# Altium schematic sheet display QVGA.SchDoc("display QVGA")
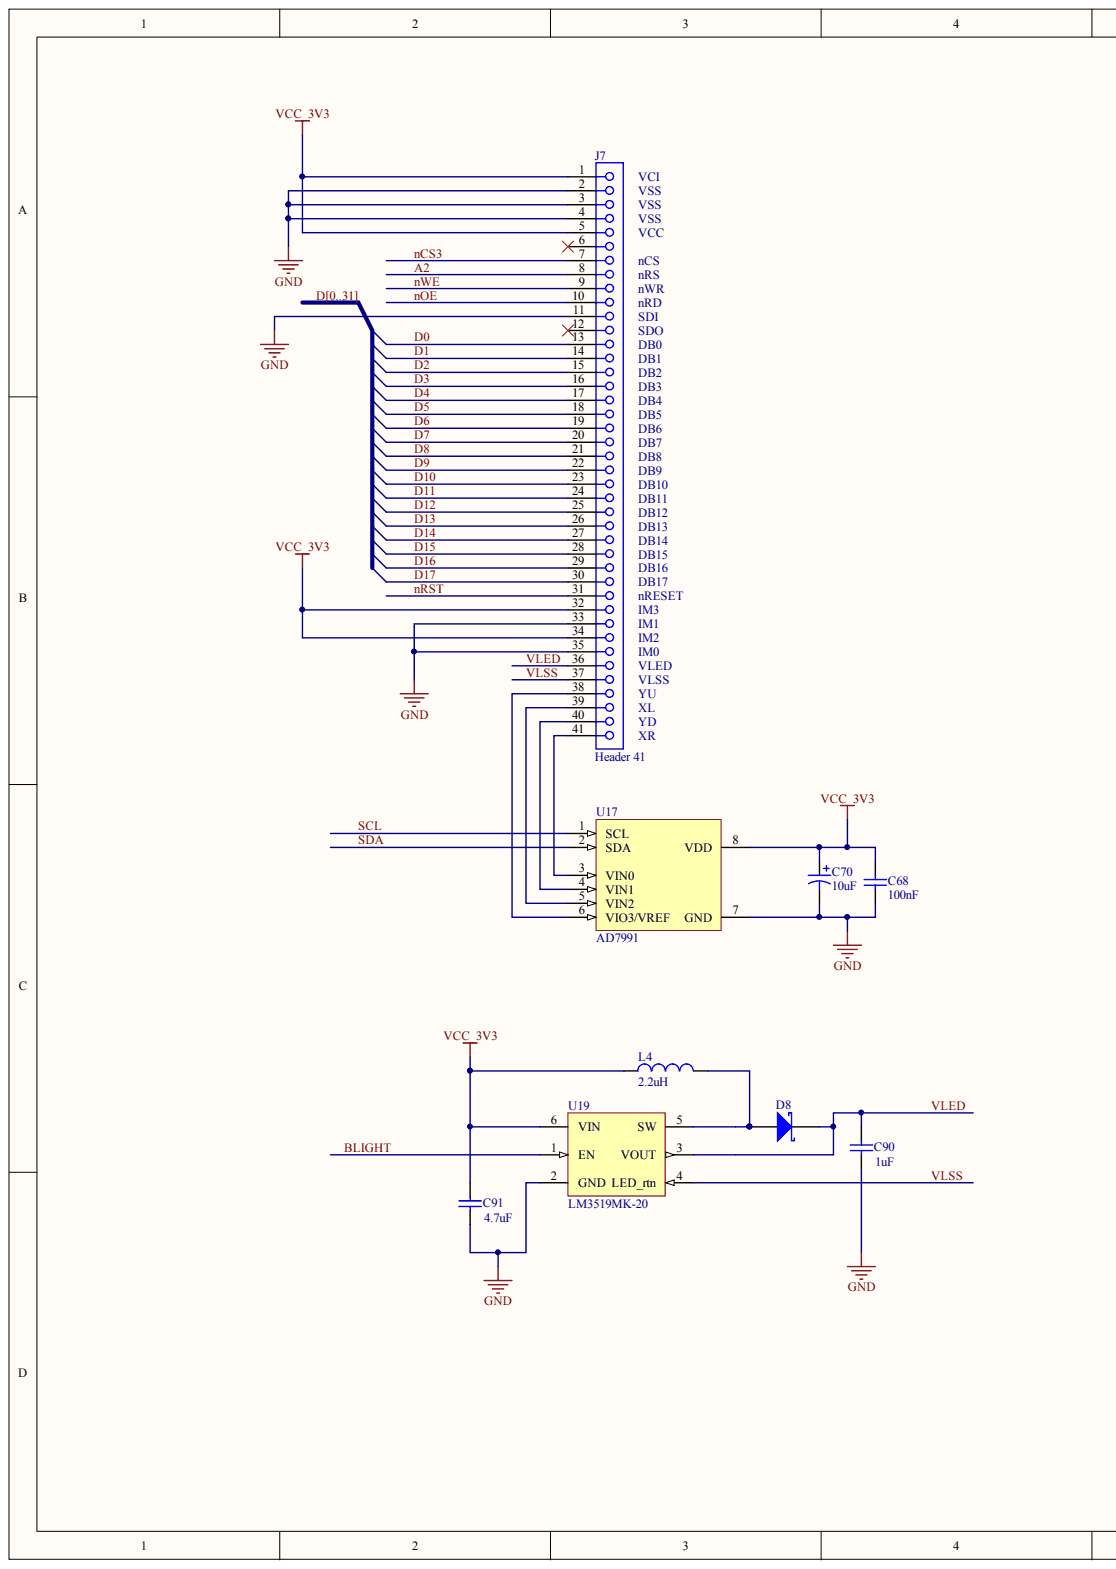
[diagram p0: display QVGA.SchDoc, left]
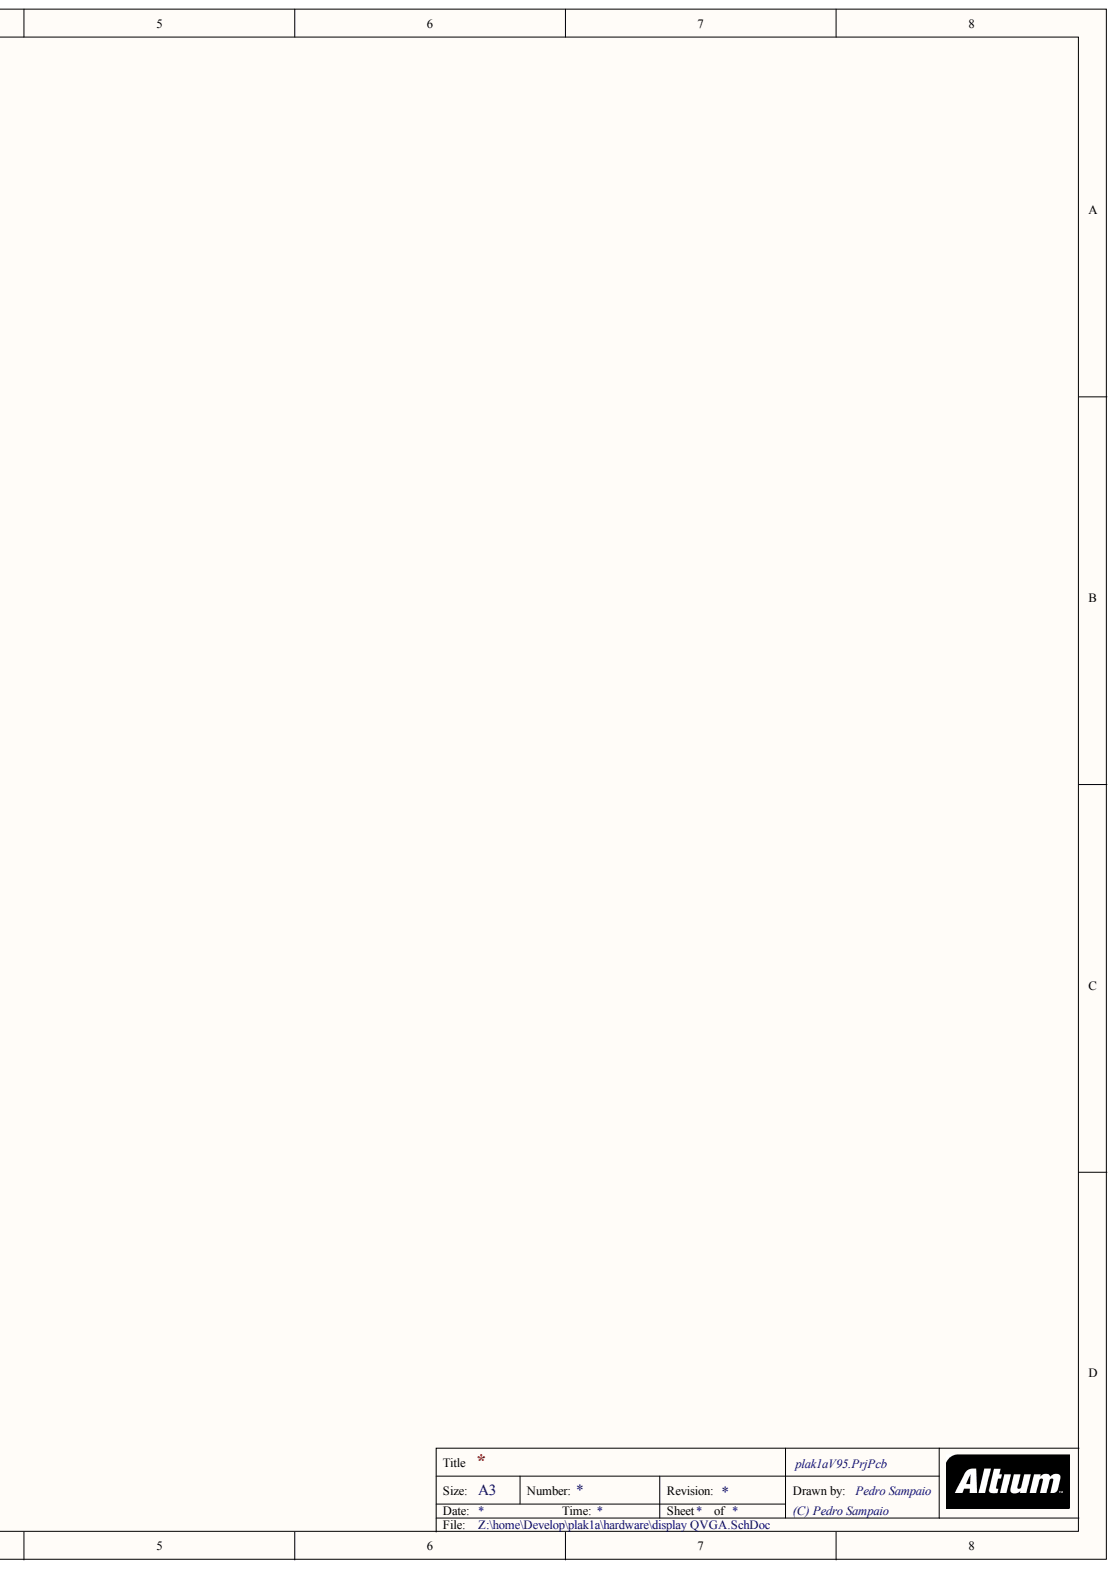
[diagram p1: display QVGA.SchDoc, right]

## Parts
C68  value 100nF  [1608[0603]]  (hidden comment: 9402101)
C70  value 10uF  [B]  (hidden comment: T491B)
C90  value 1uF  [2012[0805]]  (hidden comment: 8805628)
C91  value 4.7uF  [2012[0805]]  (hidden comment: 8805628)
D8  SS12  [SMB]  (value not drawn)
J7  Header 41  [04 6295 041 000 883+]
L4  value 2.2uH  [4564_Chip]  (hidden comment: Inductor)
U17  AD7991  [RJ-8]
U19  LM3519MK-20  [MK06A_N]

## Nets
GND: C68.1 C70.2 C90.1 C91.1 J7.2 J7.3 J7.4 J7.11 J7.33 J7.35 U17.7(GND) U19.2(GND)
VCC_3V3: C68.2 C70.1 C91.2 J7.1 J7.5 J7.32 J7.34 L4.1 U17.8(VDD) U19.6(VIN)
A2: J7.8
BLIGHT: U19.1(EN)
D0: J7.13  [also labelled D]
D1: J7.14  [also labelled D]
D2: J7.15  [also labelled D]
D3: J7.16  [also labelled D]
D4: J7.17  [also labelled D]
D5: J7.18  [also labelled D]
D6: J7.19  [also labelled D]
D7: J7.20  [also labelled D]
D8: J7.21  [also labelled D]
D9: J7.22  [also labelled D]
D10: J7.23  [also labelled D]
D11: J7.24  [also labelled D]
D12: J7.25  [also labelled D]
D13: J7.26  [also labelled D]
D14: J7.27  [also labelled D]
D15: J7.28  [also labelled D]
D16: J7.29  [also labelled D]
D17: J7.30  [also labelled D]
NCS3: J7.7
NOE: J7.10
NRST: J7.31
NWE: J7.9
SCL: U17.1(SCL)
SDA: U17.2(SDA)
VLED: C90.2 D8.2 J7.36 U19.3(VOUT)
VLSS: J7.37 U19.4(LED_rtn)
NetD8_1: D8.1 L4.2 U19.5(SW)
NetJ7_38: J7.38 U17.6(VIO3/VREF)
NetJ7_39: J7.39 U17.5(VIN2)
NetJ7_40: J7.40 U17.4(VIN1)
NetJ7_41: J7.41 U17.3(VIN0)
D18:  [also labelled D]
D19:  [also labelled D]
D20:  [also labelled D]
D21:  [also labelled D]
D22:  [also labelled D]
D23:  [also labelled D]
D24:  [also labelled D]
D25:  [also labelled D]
D26:  [also labelled D]
D27:  [also labelled D]
D28:  [also labelled D]
D29:  [also labelled D]
D30:  [also labelled D]
D31:  [also labelled D]

Not connected: J7 6 12

## Library data of the parts
C68 = C0603: Ceramic Chip Capacitor - Standard; Note: Footprint corresponds to dimensions for bulk cassette packaging. This case size has tightened tolerances.; Code_IPC: 1608 [0603]; Code_JEITA: 0603; Component Kind: Standard; ComponentLink1Description: Manufacturer Link; ComponentLink1URL: http://www.kemet.com/; ComponentLink2Description: Datasheet; ComponentLink2URL: http://www.kemet.com/kemet/web/homepage/kechome.nsf/vapubfilesname/F3102TA.pdf/$file/F3102TA.pdf; DatasheetDocument: Mar-2001; Farnell: 1301716; LatestRevisionDate: 19-Mar-2004; LatestRevisionNote: Silkscreen size reduced.; Library Name: KEMET Chip Capacitor.IntLib; PackageDocument: Mar-2001; PackageReference: 0603; Published: 17-Jul-2002; Signal Integrity: C0603; Simulation: CAP; Publisher: Altium Limited
C70 = T491B: Solid Tantalum Chip Capacitor, Standard T491 Series - Industrial Grade; Code_JEITA: 3528-21; Component Kind: Standard; ComponentLink1Description: Manufacturer Link; ComponentLink1URL: http://www.kemet.com/; ComponentLink2Description: Datasheet; ComponentLink2URL: http://www.kemet.com/kemet/web/homepage/kechome.nsf/vapubfilesname/F3102TA.pdf/$file/F3102TA.pdf; DatasheetDocument: Mar-2001; Farnell: 1301818; LatestRevisionDate: 19-Mar-2004; LatestRevisionNote: Silkscreen dimensions re-sized.; Library Name: KEMET Chip Capacitor.IntLib; PackageDocument: Mar-2001; PackageReference: B; Published: 17-Jul-2002; Signal Integrity: T491B; Simulation: CAP; Publisher: Altium Limited
C90 C91 = C0805: Ceramic Chip Capacitor - Standard; Note: Footprint corresponds to dimensions for bulk cassette packaging. This case size has tightened tolerances.; Code_IPC: 2012[0805]; Code_JEITA: 0805; Component Kind: Standard; ComponentLink1Description: Manufacturer Link; ComponentLink1URL: http://www.kemet.com/; ComponentLink2Description: Datasheet; ComponentLink2URL: http://www.kemet.com/kemet/web/homepage/kechome.nsf/vapubfilesname/F3102TA.pdf/$file/F3102TA.pdf; DatasheetDocument: Mar-2001; Farnell: 8805628; LatestRevisionDate: 19-Mar-2004; LatestRevisionNote: Silkscreen size reduced.; Library Name: KEMET Chip Capacitor.IntLib; PackageDocument: Mar-2001; PackageReference: 0805; Published: 17-Jul-2002; Signal Integrity: C0805; Simulation: CAP; Publisher: Altium Limited
D8 = D Schottky: Schottky Diode; Code_JEDEC: DO-214-AA; Component Kind: Standard; Farnell: 8647763; LatestRevisionDate: 17-Jul-2002; LatestRevisionNote: Re-released for DXP Platform.; Library Name: Miscellaneous Devices.IntLib; PackageReference: SMB; Published: 8-Jun-2000; Signal Integrity: D Schottky; Simulation: SKYDIODE; Publisher: Altium Limited
J7 = Header 41: Type, 32, Pitch 2.54 mm; DrillSize: Corresponds to nominal value.; Note: Hole Diameter is to be co-ordinated with the respective contact; Component Kind: Standard; ComponentLink1Description: Manufacturer Link; ComponentLink1URL: http://www.harting.com/; ComponentLink2Description: Packaging Specification; ComponentLink2URL: http://www.harkis.harting.com/_gds/pdf/TB_0903278x865_BL01_R31347.pdf; DatasheetDocument: 02-Jun-2003; Farnell: 1558190; Library Name: Componentes.IntLib; PackageDocument: 02-Jun-2003; PackageReference: 0903278x865; Published: 6-Oct-2005; Publisher: Altium Limited
L4 = Inductor: Code_IEC: 1005; Code_JEITA: 0402; Component Kind: Standard; Description: Inductor; LatestRevisionDate: 17-Jul-2002; LatestRevisionNote: Re-released for DXP Platform.; Library Name: Miscellaneous Devices.IntLib; PackageReference: 0402-A; Published: 8-Jun-2000; Signal Integrity: Inductor; Simulation: INDUCTOR; Publisher: Altium Limited
U17 = AD7991: 4-Channel 12-Bit ADC, I2C; Component Kind: Standard; Farnell: 1607235; Library Name: Componentes.IntLib
U19 = LM3519MK-20: High Frequency Boost White LED Driver with High-Speed PWM Brightness Control; Manufacturer: National Semiconductor; CompType: MiscPower; PackageTopMarking: D52B*XTT; Code_IPC: SOT26A-6; Code_JEDEC: MO-193-AA; Component Kind: Standard; ComponentLink1Description: LM3519 Datasheet; ComponentLink1URL: http://www.national.com/ds/LM/LM3519.pdf; ComponentLink2Description: LM3519 Web Page; ComponentLink2URL: http://www.national.com/pf/LM/LM3519.html; ComponentLink3Description: MK06A Package Page; ComponentLink3URL: http://www.national.com/packaging/folders/mk06a.html; DatasheetVersion: Sep-2005; DigiKeyPartNumber: LM3519MK-20CT-ND; Library Name: NSC MiscPower.IntLib; PackageDescription: Molded TSOT, 2.97 x 1.65 x 0.87mm Body, 6 Lead, 0.95mm Pitch; PackageReference: MK06A; PackageVersion: revD, Jul-2005; PartNumber: LM3519MK-20; PinCount: 6; Published: 27-Nov-2008; RoHS: Y; Publisher: Altium Limited
